# Altium schematic sheet FLYBACK.SchDoc(FLYBACK)
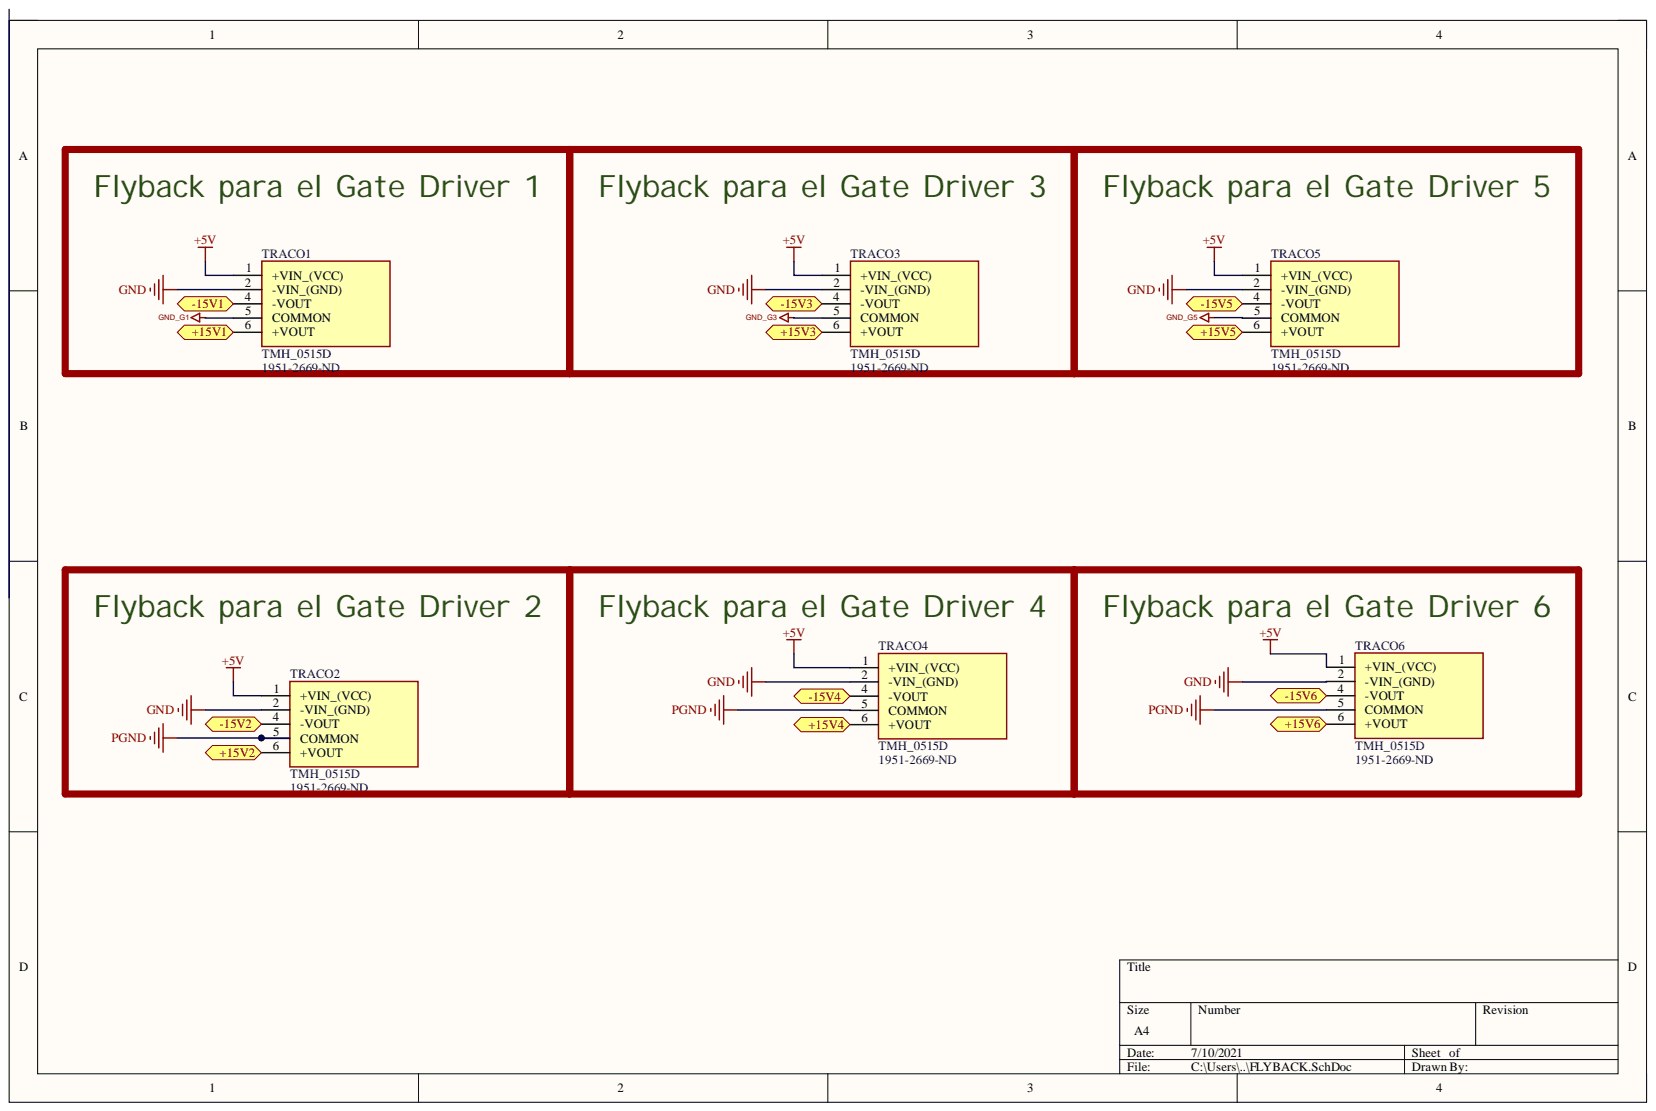
[diagram p0: FLYBACK.SchDoc]

## Parts
TRACO1  TMH_0515D  Supplier Part Number 1: 1951-2669-ND  [TMH0515D]
TRACO2  TMH_0515D  Supplier Part Number 1: 1951-2669-ND  [TMH0515D]
TRACO3  TMH_0515D  Supplier Part Number 1: 1951-2669-ND  [TMH0515D]
TRACO4  TMH_0515D  Supplier Part Number 1: 1951-2669-ND  [TMH0515D]
TRACO5  TMH_0515D  Supplier Part Number 1: 1951-2669-ND  [TMH0515D]
TRACO6  TMH_0515D  Supplier Part Number 1: 1951-2669-ND  [TMH0515D]

## Ports
+15V1, +15V2, +15V3, +15V4, +15V5, +15V6, -15V1, -15V2, -15V3, -15V4, -15V5, -15V6

## Nets
+5V: TRACO1.1(+VIN_(VCC)) TRACO2.1(+VIN_(VCC)) TRACO3.1(+VIN_(VCC)) TRACO4.1(+VIN_(VCC)) TRACO5.1(+VIN_(VCC)) TRACO6.1(+VIN_(VCC))
GND: TRACO1.2(-VIN_(GND)) TRACO2.2(-VIN_(GND)) TRACO3.2(-VIN_(GND)) TRACO4.2(-VIN_(GND)) TRACO5.2(-VIN_(GND)) TRACO6.2(-VIN_(GND))
GND_G1: TRACO1.5(COMMON)
GND_G3: TRACO3.5(COMMON)
GND_G5: TRACO5.5(COMMON)
PGND: TRACO2.5(COMMON) TRACO4.5(COMMON) TRACO6.5(COMMON)
NetTRACO1_4: TRACO1.4(-VOUT)
NetTRACO1_6: TRACO1.6(+VOUT)
NetTRACO2_4: TRACO2.4(-VOUT)
NetTRACO2_6: TRACO2.6(+VOUT)
NetTRACO3_4: TRACO3.4(-VOUT)
NetTRACO3_6: TRACO3.6(+VOUT)
NetTRACO4_4: TRACO4.4(-VOUT)
NetTRACO4_6: TRACO4.6(+VOUT)
NetTRACO5_4: TRACO5.4(-VOUT)
NetTRACO5_6: TRACO5.6(+VOUT)
NetTRACO6_4: TRACO6.4(-VOUT)
NetTRACO6_6: TRACO6.6(+VOUT)

## Library data of the parts
TRACO1-TRACO6 = TMH_0515D: DC/DC CONVERTER; Manufacturer: Traco Power; Manufacturer_Part_Number: TMH 0515D; Current - Output 1: 65mA; Efficiency: 79%; Isolation: Isolated; Isolation Voltage: 1kV; Max Input Voltage: 5.5V; Max Output Power: 2W; Min Input Voltage: 4.5V; Nominal Input Voltage: 5V; Number of Outputs: 2; Output Voltage: 15V; Voltage - Output 2: -15V; Case/Package: SIP; Category: Voltage Regulators - Switching; Component Kind: Standard; ComponentLink1Description: https://datasheet.ciiva.com/26336/157182-da-01-en-dc-dc-wandler-tmh-0515d-26336256.pdf?src-supplier=Conrad; ComponentLink1URL: https://datasheet.ciiva.com/26336/157182-da-01-en-dc-dc-wandler-tmh-0515d-26336256.pdf?src-supplier=Conrad; ComponentLink2Description: https://datasheet.ciiva.com/46393/1648746-46393670.pdf?src-supplier=Element14; ComponentLink2URL: https://datasheet.ciiva.com/46393/1648746-46393670.pdf?src-supplier=Element14; ComponentLink3Description: https://datasheet.ciiva.com/3356/tmh-eng-tds-3356425.pdf?src-supplier=Distrelec; ComponentLink3URL: https://datasheet.ciiva.com/3356/tmh-eng-tds-3356425.pdf?src-supplier=Distrelec; Current - Output 2: 65mA; Datasheet Link: https://componentsearchengine.com//TMH 0515D.pdf; Depth: 7.5mm; Flammability Rating: UL94 V-0; Height: 10.45mm; Length: 19.5mm; Manufacturer Part Number: TMH0515D; Manufacturer_Name: Traco Power; Max Input Voltage (DC): 5.5V; Max Operating Temperature: 75°C; Max Output Current: 65mA; Min Input Voltage (DC): 4.5V; Min Operating Temperature: -40°C; Mount: Through Hole; Mouser2 Part Number: 495-TMH-0515D; Mouser2 Price/Stock: https://www.mouser.com/Search/Refine.aspx?Keyword=495-TMH-0515D; Number of Pins: 5; Output Current: 65mA; Output Power: 2W; Pricing 1: 1=7.20000, 10=6.97900, 25=6.77400, 50=6.56880, 100=6.36350, 250=6.15824, 500=5.74770 (USD); Radiation Hardening: No; REACH SVHC: No SVHC; RoHS: Yes; RoHS Compliant: Yes; Series: TMH; Stock 1: 615; Supplier 1: Digi-Key; Voltage - Output 1: 15V; Weight: 2.7g; Width: 7.5mm; Library Name: sch jasiel.SchLib
